# Altium schematic sheet Relay.SchDoc
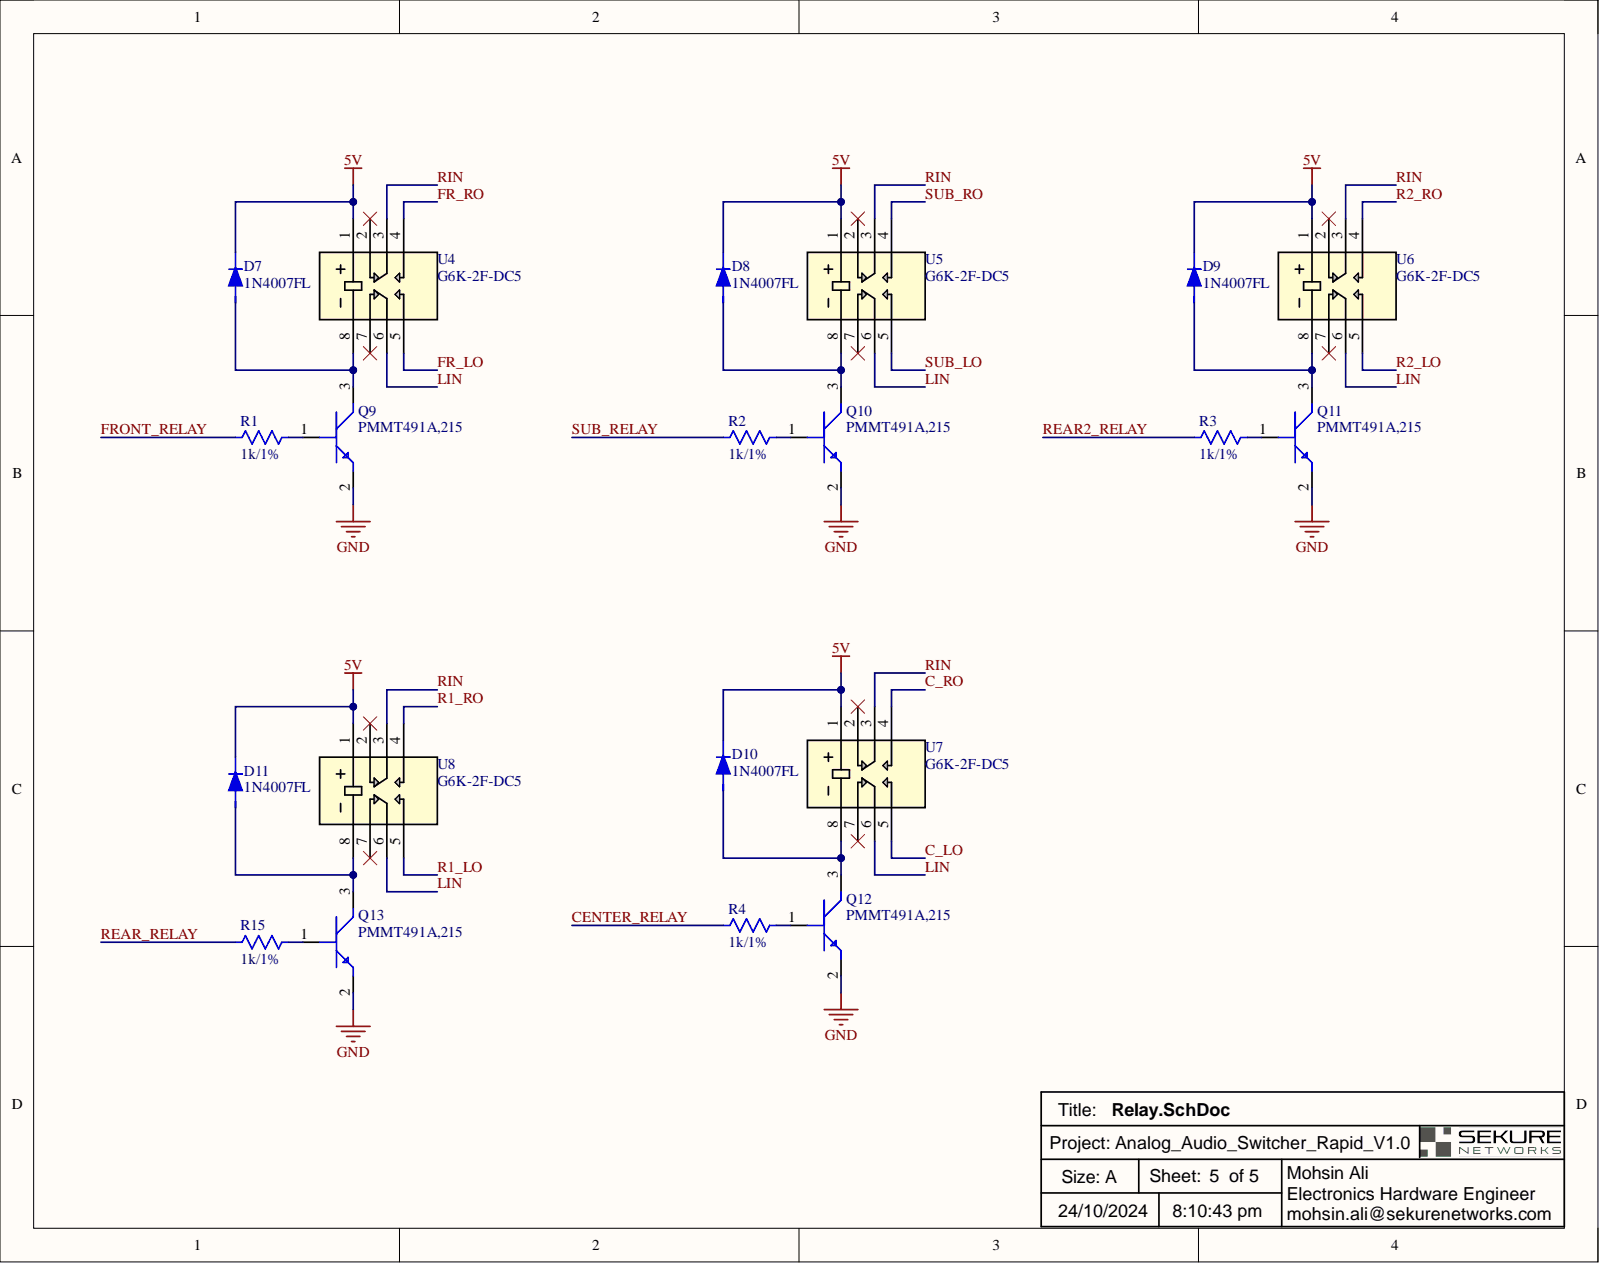
[diagram p0: Relay.SchDoc]

## Parts
D7  value 1N4007FL  [SOD-123]  (hidden comment: Diode)
D8  value 1N4007FL  [SOD-123]  (hidden comment: Diode)
D9  value 1N4007FL  [SOD-123]  (hidden comment: Diode)
D10  value 1N4007FL  [SOD-123]  (hidden comment: Diode)
D11  value 1N4007FL  [SOD-123]  (hidden comment: Diode)
Q9  value PMMT491A,215  [SOT23]  (hidden comment: Transistor)
Q10  value PMMT491A,215  [SOT23]  (hidden comment: Transistor)
Q11  value PMMT491A,215  [SOT23]  (hidden comment: Transistor)
Q12  value PMMT491A,215  [SOT23]  (hidden comment: Transistor)
Q13  value PMMT491A,215  [SOT23]  (hidden comment: Transistor)
R1  value 1k/1%  [RES 0603]  (hidden comment: Resistor)
R2  value 1k/1%  [RES 0603]  (hidden comment: Resistor)
R3  value 1k/1%  [RES 0603]  (hidden comment: Resistor)
R4  value 1k/1%  [RES 0603]  (hidden comment: Resistor)
R15  value 1k/1%  [RES 0603]  (hidden comment: Resistor)
U4  value G6K-2F-DC5  [RELAY_G6K-2F DC3_OMR]  (hidden comment: Relay)
U5  value G6K-2F-DC5  [RELAY_G6K-2F DC3_OMR]  (hidden comment: Relay)
U6  value G6K-2F-DC5  [RELAY_G6K-2F DC3_OMR]  (hidden comment: Relay)
U7  value G6K-2F-DC5  [RELAY_G6K-2F DC3_OMR]  (hidden comment: Relay)
U8  value G6K-2F-DC5  [RELAY_G6K-2F DC3_OMR]  (hidden comment: Relay)

## Nets
5V: D7.1 D8.1 D9.1 D10.1 D11.1 U4.1 U5.1 U6.1 U7.1 U8.1
GND: Q9.2 Q10.2 Q11.2 Q12.2 Q13.2
C_LO: U7.5
C_RO: U7.4
CENTER_RELAY: R4.1
FR_LO: U4.5
FR_RO: U4.4
FRONT_RELAY: R1.1
LIN: U4.6 U5.6 U6.6 U7.6 U8.6
R1_LO: U8.5
R1_RO: U8.4
R2_LO: U6.5
R2_RO: U6.4
REAR2_RELAY: R3.1
REAR_RELAY: R15.1
RIN: U4.3 U5.3 U6.3 U7.3 U8.3
SUB_LO: U5.5
SUB_RELAY: R2.1
SUB_RO: U5.4
NetD7_2: D7.2 Q9.3 U4.8
NetD8_2: D8.2 Q10.3 U5.8
NetD9_2: D9.2 Q11.3 U6.8
NetD10_2: D10.2 Q12.3 U7.8
NetD11_2: D11.2 Q13.3 U8.8
NetQ9_1: Q9.1 R1.2
NetQ10_1: Q10.1 R2.2
NetQ11_1: Q11.1 R3.2
NetQ12_1: Q12.1 R4.2
NetQ13_1: Q13.1 R15.2

Not connected: U4 2 7; U5 2 7; U6 2 7; U7 2 7; U8 2 7

## Library data of the parts
D7-D11 = 1N4007FL: Diode Gen Purp 1KV 1A SOD123FL; Manufacturer: Sangdest Microelectronics; Max Forward Surge Current (Ifsm): 30A; Max Reverse Current: 5uA; Category: Diodes; Component Kind: Standard; DigiKey: 1655-1N4007FLCT-ND; Forward Current: 1A; Height: 1.1mm; Library Name: Diode.IntLib; Max Operating Temperature: 150°C; Max Repetitive Reverse Voltage (Vrrm): 1kV; Min Operating Temperature: -55°C; P/N: 1N4007FL; Pricing: 1=0.1, 10=0.058, 100=0.039, 500=0.02954, 1000=0.02627 (USD); Reverse Voltage: 1kV; RoHS: No; Stock: 332632; Supplier: Digikey
Q9-Q13 = PMMT491A,215: Small Signal Bipolar Transistor, 1A I(C), 40V V(BR)CEO, 1-Element, NPN, Silicon, TO-236AB; Manufacturer: Nexperia; DC Current Gain-Min (hFE): 200; Frequency: 150MHz; Number of Elements: 1; Package Body Material: Plastic; Time @ Peak Reflow Temperature-Max (s): 30s; Transistor Application: SWITCHING; Category: BJTs; Collector-emitter Voltage-Max: 40V; Component Kind: Standard; ComponentLink1Description: https://datasheet.ciiva.com:443/pdfs/VipMasterIC/IC/NEXP/NEXP-S-A0002881467/NEXP-S-A0002882567-1.pdf?src-supplier=IHS+Markit; ComponentLink1URL: https://datasheet.ciiva.com:443/pdfs/VipMasterIC/IC/NEXP/NEXP-S-A0002881467/NEXP-S-A0002882567-1.pdf?src-supplier=IHS+Markit; ComponentLink2Description: https://datasheet.octopart.com/PMMT491A%2C215-Nexperia-datasheet-10337295.pdf?src-supplier=Farnell; ComponentLink2URL: https://datasheet.octopart.com/PMMT491A%2C215-Nexperia-datasheet-10337295.pdf?src-supplier=Farnell; ComponentLink3Description: https://datasheet.octopart.com/PMMT491A%2C215-Nexperia-datasheet-87582102.pdf?src-supplier=TME; ComponentLink3URL: https://datasheet.octopart.com/PMMT491A%2C215-Nexperia-datasheet-87582102.pdf?src-supplier=TME; DigiKey: 1727-5220-1-ND; JEDEC-95 Code: TO-236AB; JESD-30 Code: R-PDSO-G3; JESD-609 Code: e3; Library Name: Transistor.IntLib; Max Collector Current: 1A; Moisture Sensitivity Level: 1; P/N: PMMT491A,215; Package Shape: Rectangular; Package Style: SMALL OUTLINEMeter; Peak Reflow Temperature (Cel): 260°C; Pins: 3; Polarity/Channel Type: NPN; Pricing: 1=0.34, 10=0.239, 100=0.1207, 500=0.09844, 1000=0.07303 (USD); Reach SVHC Compliant: Yes; RoHS: Yes; RoHS Compliant: Yes; Stock: 36431; Supplier: Digikey; Surface Mount: Yes; Terminal Finish: Tin; Terminal Form: Gull Wing; Terminal Position: DUAL; Transistor Element Material: SILICON
R1-R4 R15 = CRCW06031K00FKEA: RES SMD 1 kohm 1% Thick Film, 100 mW; Manufacturer: Vishay Dale; Max Power Dissipation: 100mW; Resistance: 1kR; Temperature Coefficient: 100ppm/°C; Voltage Rating: 75V; Case Code (Imperial): 0603; Case Code (Metric): 1608; Case/Package: 0603; Component Kind: Standard; ComponentLink1Description: https://datasheet.ciiva.com/11808/0900766b80d98afc-11808625.pdf?src-supplier=RSComponents; ComponentLink1URL: https://datasheet.ciiva.com/11808/0900766b80d98afc-11808625.pdf?src-supplier=RSComponents; ComponentLink2Description: https://datasheet.ciiva.com/2465/dcrcwe3-2465536.pdf?src-supplier=Digi-Key; ComponentLink2URL: https://datasheet.ciiva.com/2465/dcrcwe3-2465536.pdf?src-supplier=Digi-Key; ComponentLink3Description: https://datasheet.ciiva.com/8336/dcrcwe3-8336822.pdf?src-supplier=Avnet; ComponentLink3URL: https://datasheet.ciiva.com/8336/dcrcwe3-8336822.pdf?src-supplier=Avnet; ComponentLink4Description: https://datasheet.ciiva.com/16515/dcrcwe3-16515078.pdf?src-supplier=Avnet+Asia; ComponentLink4URL: https://datasheet.ciiva.com/16515/dcrcwe3-16515078.pdf?src-supplier=Avnet+Asia; ComponentLink5Description: https://datasheet.ciiva.com/46441/1720486-46441622.pdf?src-supplier=Element14; ComponentLink5URL: https://datasheet.ciiva.com/46441/1720486-46441622.pdf?src-supplier=Element14; ComponentLink6Description: https://datasheet.ciiva.com/26316/fld-crcw-e3-data-en-26316551.pdf?src-supplier=Distrelec; ComponentLink6URL: https://datasheet.ciiva.com/26316/fld-crcw-e3-data-en-26316551.pdf?src-supplier=Distrelec; ComponentLink7Description: https://datasheet.ciiva.com/46441/1720486-46441623.pdf?src-supplier=Element14; ComponentLink7URL: https://datasheet.ciiva.com/46441/1720486-46441623.pdf?src-supplier=Element14; ComponentLink8Description: https://datasheet.ciiva.com/46385/1697337-46385497.pdf?src-supplier=Element14; ComponentLink8URL: https://datasheet.ciiva.com/46385/1697337-46385497.pdf?src-supplier=Element14; ComponentLink9Description: https://datasheet.ciiva.com/3257/dcrcwe3-3257132.pdf?src-supplier=Avnet; ComponentLink9URL: https://datasheet.ciiva.com/3257/dcrcwe3-3257132.pdf?src-supplier=Avnet; ComponentLink10Description: https://datasheet.ciiva.com/16199/dcrcwe3-16199053.pdf?src-supplier=Future+Electronics; ComponentLink10URL: https://datasheet.ciiva.com/16199/dcrcwe3-16199053.pdf?src-supplier=Future+Electronics; ComponentLink11Description: https://datasheet.ciiva.com/2465/dcrcwe3-2465534.pdf?src-supplier=Digi-Key; ComponentLink11URL: https://datasheet.ciiva.com/2465/dcrcwe3-2465534.pdf?src-supplier=Digi-Key; ComponentLink12Description: https://datasheet.ciiva.com/16893/dcrcwe3-16893742.pdf?src-supplier=Avnet+Europe; ComponentLink12URL: https://datasheet.ciiva.com/16893/dcrcwe3-16893742.pdf?src-supplier=Avnet+Europe; ComponentLink13Description: https://datasheet.ciiva.com/2465/dcrcwe3-2465535.pdf?src-supplier=Digi-Key; ComponentLink13URL: https://datasheet.ciiva.com/2465/dcrcwe3-2465535.pdf?src-supplier=Digi-Key; Composition: Thick Film; Contact Plating: Tin; Depth: 0.85mm; DigiKey: 541-1.00KHCT-ND; Features: Automotive AEC-Q200; Height: 0.45mm; Lead Free: Lead Free; Length: 1.55mm; Library Name: Resistors.IntLib; Max Operating Temperature: 155°C; Military Standard: Not; Min Operating Temperature: -55°C; Mount: Surface Mount; Number of Pins: 2; Number of Terminations: 2; P/N: CRCW06031K00FKEA; Package Quantity: 10; Packaging: Tape and Reel; Power Rating: 100mW; Pricing 1: 1=0.10000, 10=0.03400, 100=0.01390, 1000=0.00623, 2500=0.00540 (USD); Radiation Hardening: No; REACH SVHC: Unknown; RoHS: Yes; Stock 1: 1070064; Supplier 1: Digi-Key; Termination: SMD/SMT; Tolerance: 1%; Voltage Rating (DC): 75V; Weight: 7.1E-05oz; Width: 0.033inch
U4-U8 = G6K-2F-DC5: Electromechanical Relay 5VDC 237Ohm 1A DPDT (10x7.8x5.2)mm SMD Signal Relay; Manufacturer: Omron; Category: Signal Relays; Component Kind: Standard; ComponentLink1Description: https://datasheet.ciiva.com/26921/getdatasheetpartid-766585-26921120.pdf?src-supplier=Verical; ComponentLink1URL: https://datasheet.ciiva.com/26921/getdatasheetpartid-766585-26921120.pdf?src-supplier=Verical; ComponentLink2Description: https://datasheet.ciiva.com/30212/81en-g6k-30212101.pdf?src-supplier=Onlinecomponents.com; ComponentLink2URL: https://datasheet.ciiva.com/30212/81en-g6k-30212101.pdf?src-supplier=Onlinecomponents.com; DigiKey: Z112-ND; Library Name: Relays.IntLib; P/N: G6K-2F-DC5; Pricing: 1=4.56, 10=3.98, 25=3.772, 50=3.6214, 100=3.477, 250=3.2948, 500=3.16336, 1000=3.03718 (USD); RoHS: Yes; Stock: 6822; Supplier: Digikey
